# Altium schematic sheet UART.SchDoc(UART)
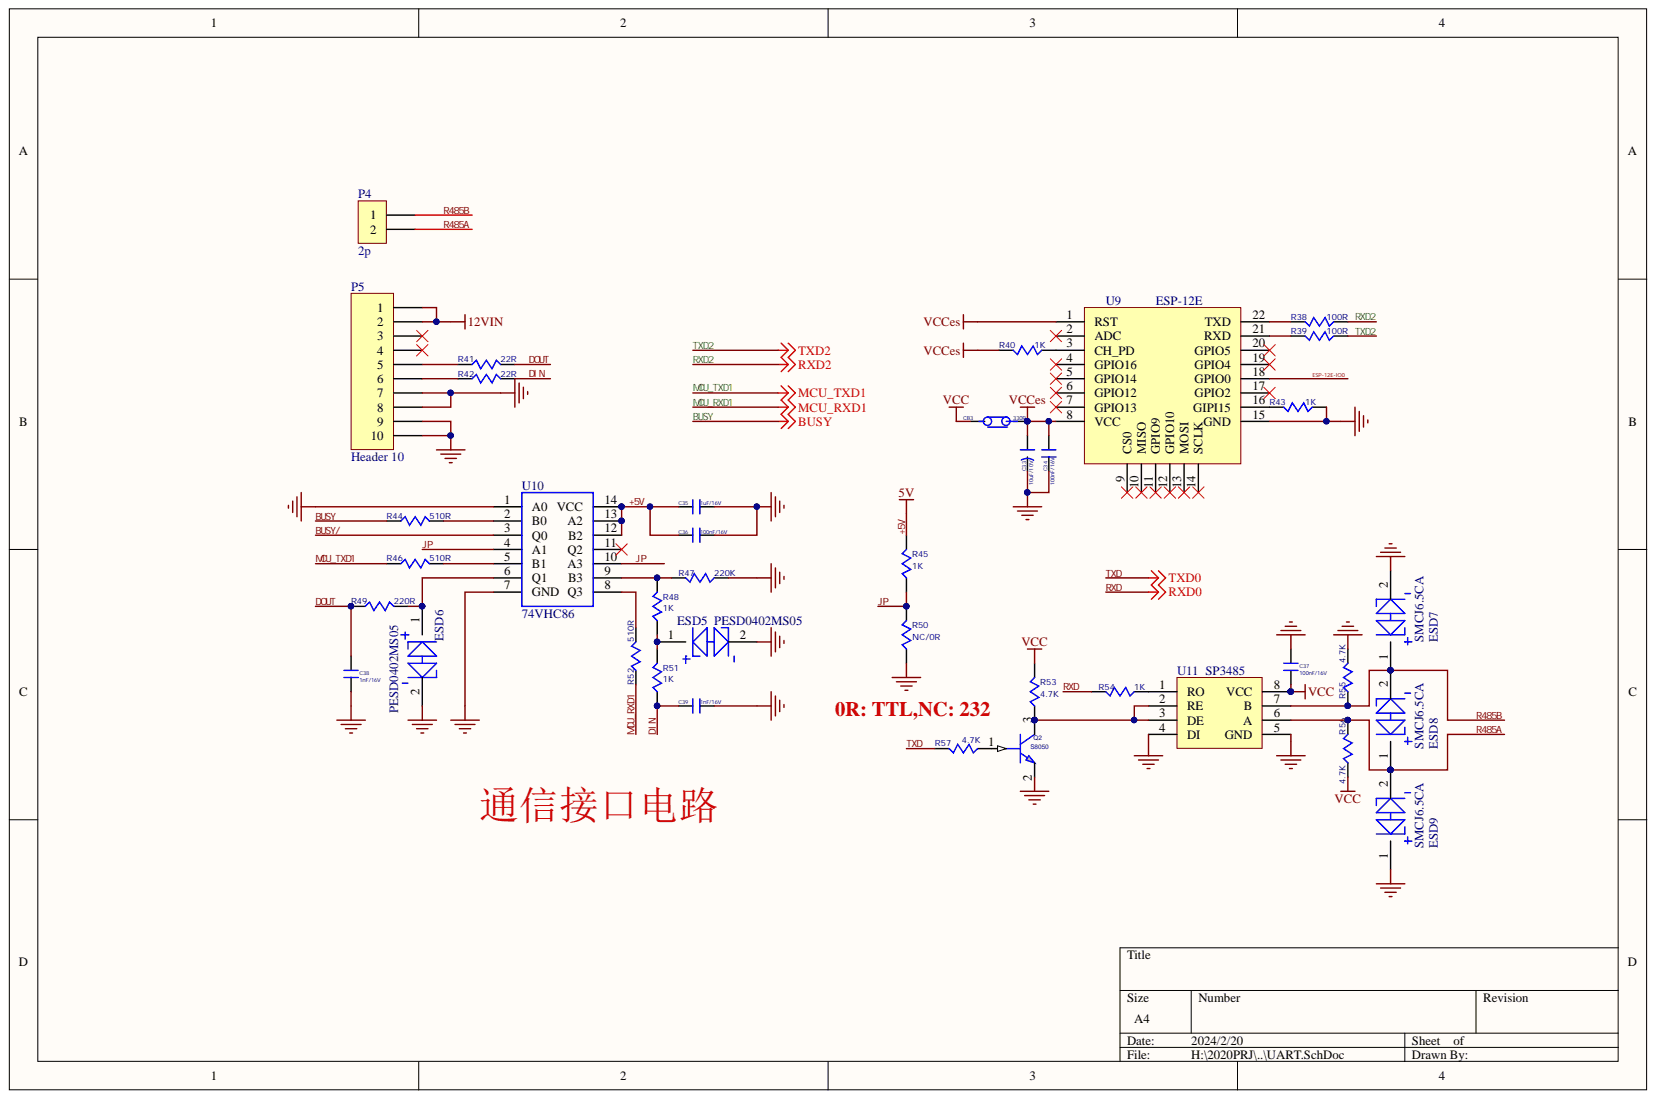
[diagram p0: UART.SchDoc]

## Parts
C33  10uF/10V  [C0603]
C34  100nF/16V  [C0402]
C35  1uF/16V  [C0402]
C36  100nF/16V  [C0402]
C37  100nF/16V  [C0402]
C38  1nF/16V  [C0402]
C39  1nF/16V  [C0402]
CB3  330R  [L0603]
ESD5  PESD0402MS05  [ESD5621W15]
ESD6  PESD0402MS05  [ESD5621W15]
ESD7  SMCJ6.5CA  [DO-214AA]
ESD8  SMCJ6.5CA  [DO-214AA]
ESD9  SMCJ6.5CA  [DO-214AA]
P4  2p  [2P]
P5  Header 10  [PH2.0]
Q2  S8050  [SOT-23]
R38  100R  [R0402]
R39  100R  [R0402]
R40  1K  [R0402]
R41  22R  [R0402]
R42  22R  [R0402]
R43  1K  [R0402]
R44  510R  [R0402]
R45  1K  [R0402]
R46  510R  [R0402]
R47  220K  [R0402]
R48  1K  [R0402]
R49  220R  [R0402]
R50  NC/0R  [R0402]
R51  1K  [R0402]
R52  510R  [R0402]
R53  4.7K  [R0402]
R54  1K  [R0402]
R55  4.7K  [R0402]
R56  4.7K  [R0402]
R57  4.7K  [R0402]
U9  ESP-12E  [ESP-12E]
U10  74VHC86  [74VHC86]
U11  SP3485  [SOP8X]

## Nets
12VIN: P5.1 P5.2
GND: C33.2 C34.2 C35.1 C36.1 C37.1 C38.2 C39.1 ESD5.2 ESD6.2 ESD7.2 ESD9.1 P5.7 P5.8 P5.9 P5.10 Q2.2 R43.1 R47.1 R50.1 R55.2 U9.15(GND) U10.1(A0) U10.7(GND) U11.4(DI) U11.5(GND)
VCC: C37.2 CB3.1 R53.2 R56.1 U11.8(VCC)
VCCES: C33.1 C34.1 CB3.2 R40.2 U9.1(RST) U9.8(VCC)
+5V: C35.2 C36.2 R45.2 U10.12(B2) U10.13(A2) U10.14(VCC)
BUSY: R44.1
BUSY/: U10.3(Q0)
DIN: C39.2 R42.2 R51.1
DOUT: C38.1 R41.2 R49.1
ESP-12E-IO0: U9.18(GPIO0)
JP: R45.1 R50.2 U10.4(A1) U10.10(A3)
MCU_RXD1: R52.1
MCU_TXD1: R46.1
R485A: ESD8.1 ESD9.2 P4.2 R56.2 U11.6(A)
R485B: ESD7.1 ESD8.2 P4.1 R55.1 U11.7(B)
RXD: R54.2
RXD2: R38.1
TXD: R57.2
TXD2: R39.1
NetESD5_1: ESD5.1 R48.1 R51.2
NetESD6_1: ESD6.1 R49.2 U10.6(Q1)
NetP5_5: P5.5 R41.1
NetP5_6: P5.6 R42.1
NetQ2_1: Q2.1 R57.1
NetQ2_3: Q2.3 R53.1 U11.2(RE) U11.3(DE)
NetR38_2: R38.2 U9.22(TXD)
NetR39_2: R39.2 U9.21(RXD)
NetR40_1: R40.1 U9.3(CH_PD)
NetR43_2: R43.2 U9.16(GIPI15)
NetR44_2: R44.2 U10.2(B0)
NetR46_2: R46.2 U10.5(B1)
NetR47_2: R47.2 R48.2 U10.9(B3)
NetR52_2: R52.2 U10.8(Q3)
NetR54_1: R54.1 U11.1(RO)

Not connected: P5 3 4; U9 2(ADC) 4(GPIO16) 5(GPIO14) 6(GPIO12) 7(GPIO13) 9(CS0) 10(MISO) 11(GPIO9) 12(GPIO10) 13(MOSI) 14(SCLK) 17(GPIO2) 19(GPIO4) 20(GPIO5); U10 11(Q2)

## Library data of the parts
C33 = C-CS1A101M-CRD54: 贴片电容47uF_1206_10V; 品牌: ST(先科); 封装规格: SMD,5x5.4mm; Component Kind: Standard; 厂家型号: CS1A101M-CRD54
C34-C39 = 0805F106M250NT: 贴片电容10uF_0805_16V; 品牌: FH(风华); 封装规格: 0805; Component Kind: Standard; 厂家型号: 0805F106M250NT
CB3 = CBW201209U331T: 贴片磁珠330R/100MHZ_500MA_0805; 品牌: FH(风华); 封装规格: 0805; Component Kind: Standard; 厂家型号: CBW201209U331T
ESD5-ESD9 = ESD5621W15: OR ROV20-180M; Component Kind: Standard
P5 = Header 10: Header, 10-Pin; Component Kind: Standard; LatestRevisionDate: 17-Jul-2002; LatestRevisionNote: Re-released for DXP Platform.; Library Name: Miscellaneous Connectors.IntLib; Signal Integrity: Connector; Publisher: Altium Limited
Q2 = NPN(BEC)_0: Component Kind: Standard
R38-R57 = RS-05L8R2JT: 贴片电阻; 品牌: FH(风华); 封装规格: 0805; Component Kind: Standard; 厂家型号: RS-05L8R2JT
P4 = 2p: Component Kind: Standard; Library Name: EA4313.SCHLIB
U9 = ESP-12E: Component Kind: Standard; Library Name: EU7013_1.SCHLIB
U10 = 74VHC86: Component Kind: Standard
U11 = SP3485: Component Kind: Standard; Library Name: EU7013_1.SCHLIB
